# Altium schematic sheet PMB_top_v2.SchDoc
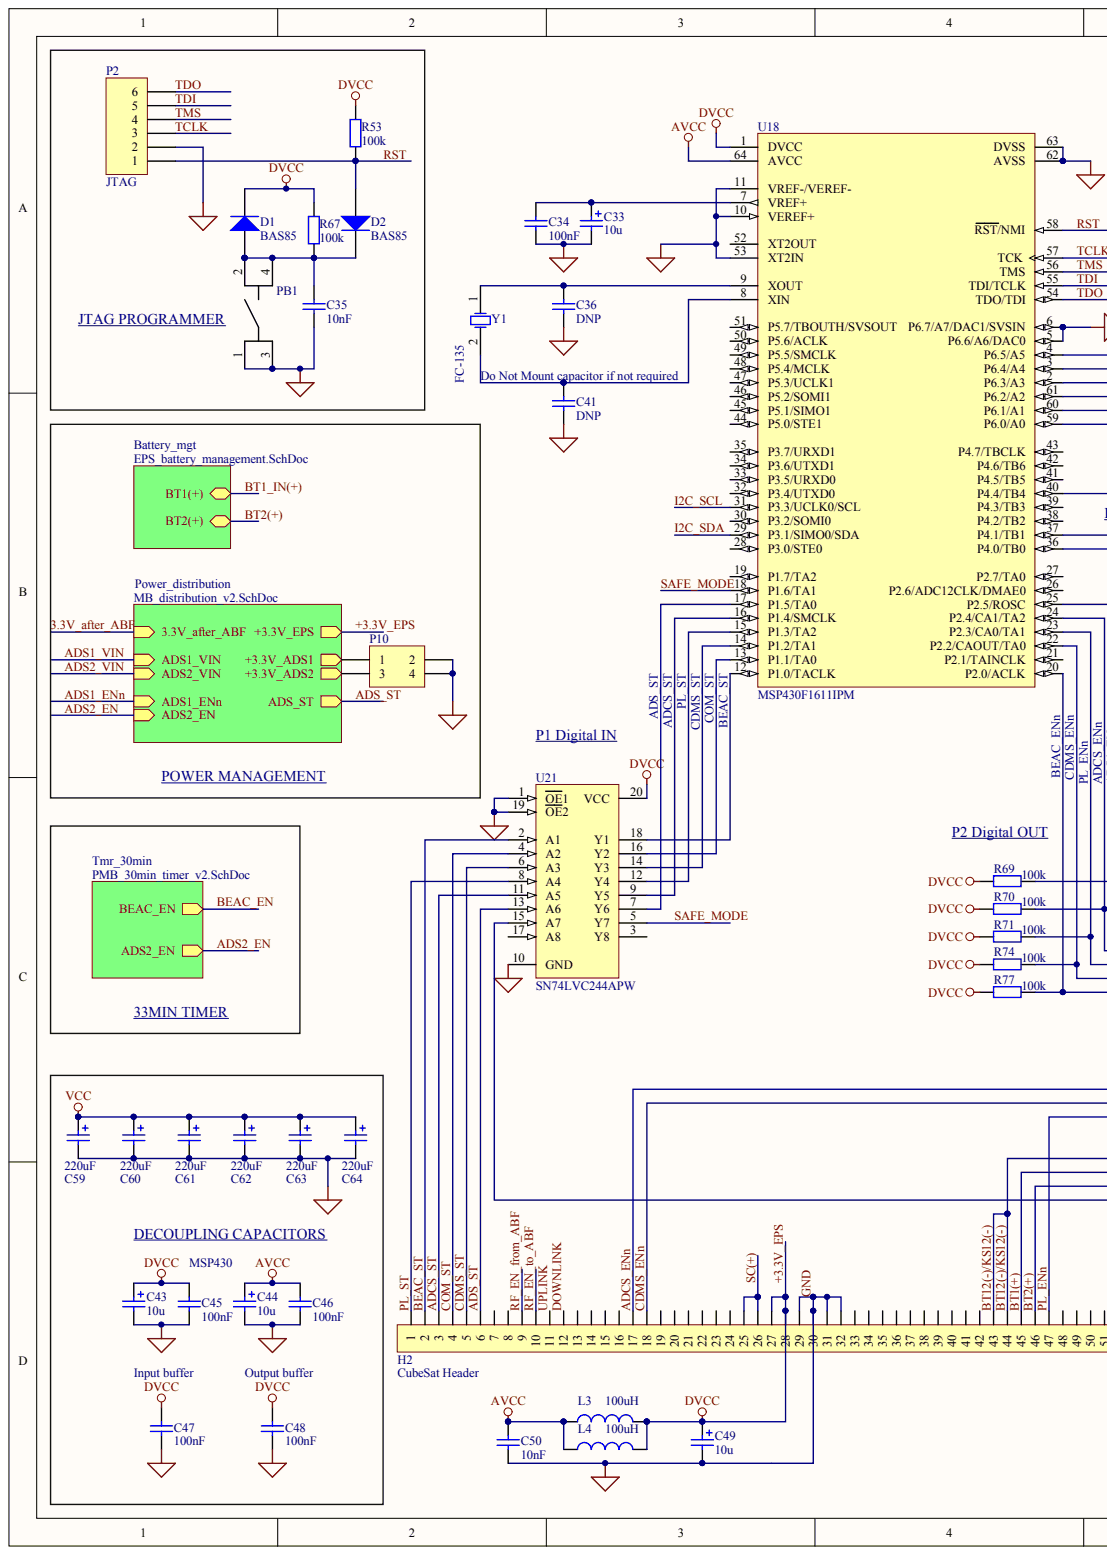
[diagram p0: PMB_top_v2.SchDoc, left]
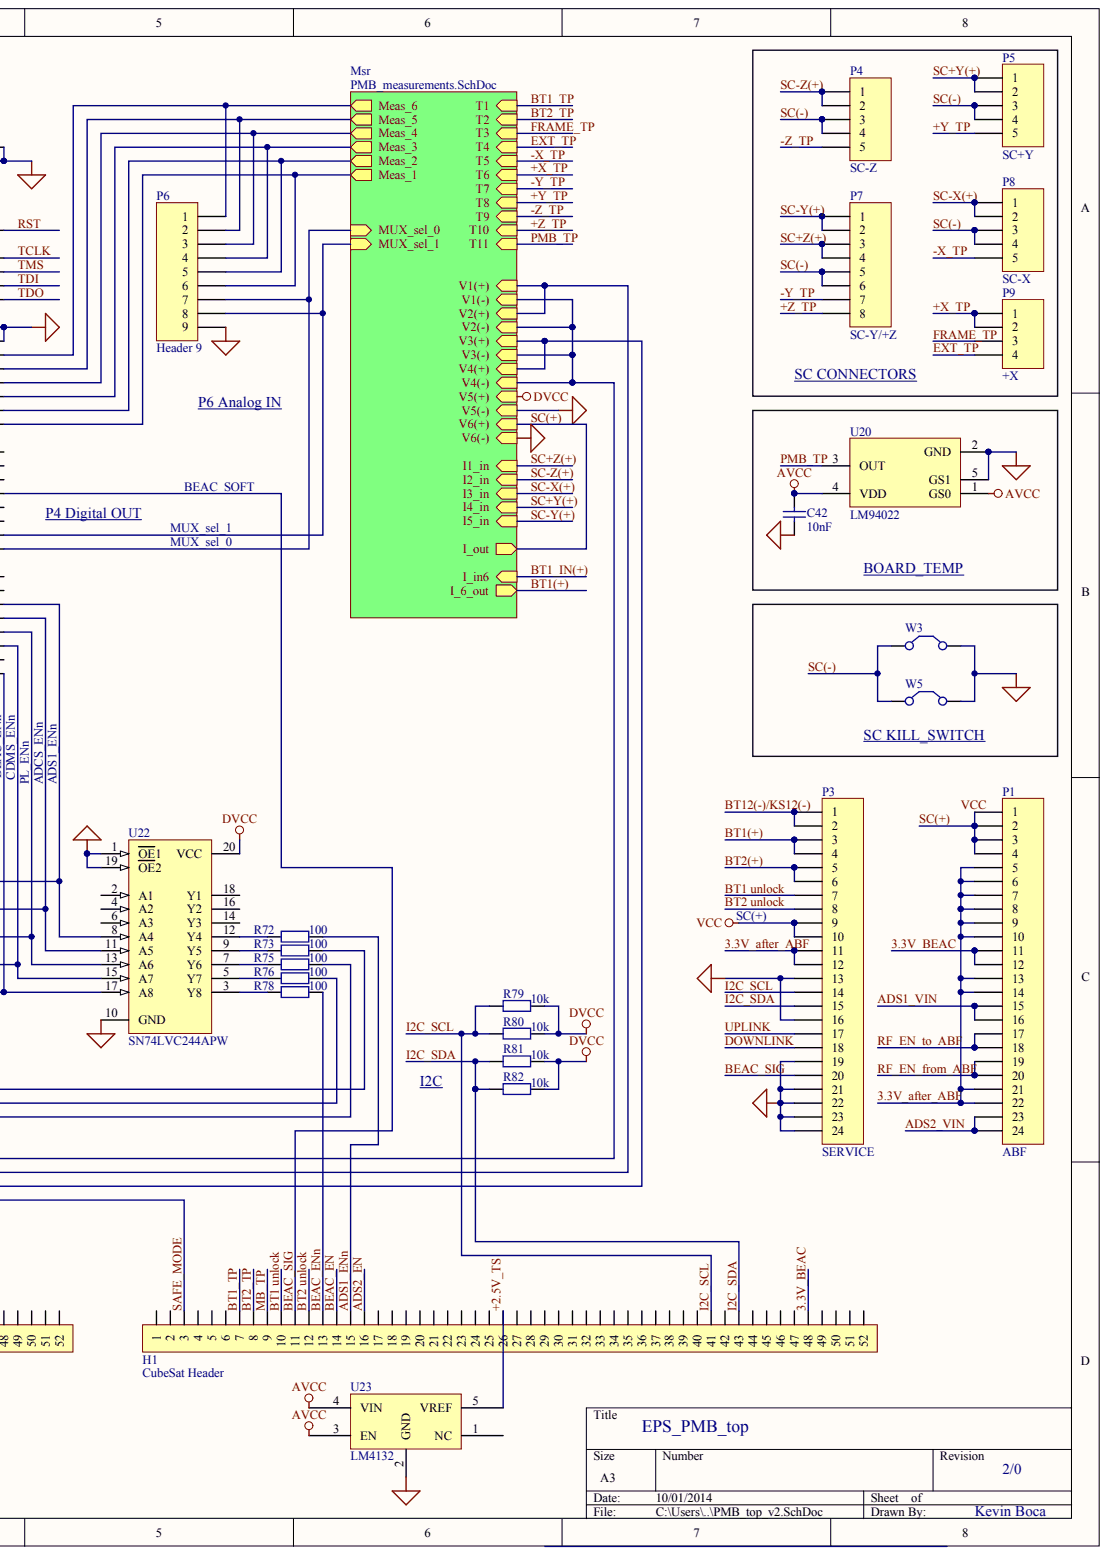
[diagram p1: PMB_top_v2.SchDoc, right]

## Parts
C33  10u
C34  100nF
C35  10nF
C36  DNP
C41  DNP
C42  10nF
C43  10u
C44  10u
C45  100nF
C46  100nF
C47  100nF
C48  100nF
C49  10u
C50  10nF
C59  220uF
C60  220uF
C61  220uF
C62  220uF
C63  220uF
C64  220uF
D1  BAS85
D2  BAS85
H1  CubeSat Header
H2  CubeSat Header
L3  100uH
L4  100uH
P1  ABF
P2  JTAG
P3  SERVICE
P4  SC-Z
P5  SC+Y
P6  Header 9
P7  SC-Y/+Z
P8  SC-X
P9  +X
P10  Header 2X2  (value not drawn)
PB1  PB
R53  100k
R67  100k
R69  100k
R70  100k
R71  100k
R72  100
R73  100
R74  100k
R75  100
R76  100
R77  100k
R78  100
R79  10k
R80  10k
R81  10k
R82  10k
U18  MSP430F1611IPM
U20  LM94022
U21  SN74LVC244APW
U22  SN74LVC244APW
U23  LM4132
W3  Jumper  (value not drawn)
W5  Jumper  (value not drawn)
Y1  FC-135

## Nets
AVCC: C42.1 C44.1 C46.2 C50.2 L3.1 L4.1 U18.64(AVCC) U20.1(GS0) U20.4(VDD) U23.3(EN) U23.4(VIN)
3.3V_AFTER_ABF: P1.5 P1.6 P1.7 P1.8 P1.9 P1.10 P1.13 P1.14 P1.21 P1.22 P3.11 P3.12
3.3V_BEAC: H1.48 P1.11 P1.12
+2.5V_TS: H1.26 U23.5(VREF)
+3.3V_EPS: C43.1 C45.2 C47.2 C48.2 C49.1 D1.1 H2.27 H2.28 L3.2 L4.2 R53.1 R67.1 R69.2 R70.2 R71.2 R74.2 R77.2 R79.1 R80.1 R81.1 R82.1 U18.1(DVCC) U21.20(VCC) U22.20(VCC)
+X_TP: P9.1 P9.2
+Y_TP: P5.5
+Z_TP: P7.8
-X_TP: P8.5
-Y_TP: P7.7
-Z_TP: P4.5
ADCS_ENN: H2.17 R73.1
ADCS_ST: H2.3 U21.11(A5)
ADS1_ENN: H1.15 R72.1
ADS1_VIN: P1.15 P1.16
ADS2_EN: H1.16
ADS2_VIN: P1.23 P1.24
ADS_ST: H2.6 U21.13(A6)
BEAC_EN: H1.14
BEAC_ENN: H1.13 R78.1
BEAC_SIG: H1.11 P3.20 U18.40(P4.4/TB4)
BEAC_ST: H2.2 U21.2(A1)
BT1 UNLOCK: H1.10 P3.7
BT1(+): H2.45 P3.3 P3.4
BT1_TP: H1.7
BT2 UNLOCK: H1.12 P3.8
BT2(+): H2.46 P3.5 P3.6
BT2_TP: H1.8
BT12(-)/KS12(-): H2.43 H2.44 P3.1 P3.2
CDMS_ENN: H2.18 R76.1
CDMS_ST: H2.5 U21.6(A3)
COM_ST: H2.4 U21.4(A2)
DOWNLINK: H2.12 P3.18
EXT_TP: P9.4
FRAME_TP: P9.3
GND: C33.2 C34.1 C35.1 C36.1 C41.1 C42.2 C43.2 C44.2 C45.1 C46.1 C47.1 C48.1 C49.2 C50.1 C59.2 C60.2 C61.2 C62.2 C63.2 C64.2 H2.29 H2.30 H2.31 H2.32 P2.2 P3.13 P3.16 P3.19 P3.21 P3.22 P3.23 P3.24 P6.9 P10.2 P10.4 PB1.1 PB1.3 U18.5(P6.6/A6/DAC0) U18.6(P6.7/A7/DAC1/SVSIN) U18.10(VEREF+) U18.11(VREF-/VEREF-) U18.53(XT2IN) U18.62(AVSS) U18.63(DVSS) U20.2(GND) U20.5(GS1) U21.1(OE1) U21.10(GND) U21.19(OE2) U22.1(OE1) U22.10(GND) U22.19(OE2) U23.2(GND) W3.2 W5.2
I2C_SCL: H1.41 P3.14 R79.2 R80.2 U18.31(P3.3/UCLK0/SCL)
I2C_SDA: H1.43 P3.15 R81.2 R82.2 U18.29(P3.1/SIMO0/SDA)
MB_TP: H1.9
PL_ENN: H2.47 R75.1
PL_ST: H2.1 U21.8(A4)
PMB_TP: U20.3(OUT)
RF_EN_FROM_ABF: H2.9 P1.19 P1.20
RF_EN_TO_ABF: H2.10 P1.17 P1.18
RST: D2.2 P2.1 R53.2 U18.58(RST/NMI)
SAFE_MODE: H1.3 U18.18(P1.6/TA1) U21.5(Y7) U21.15(A7)
SC(+): H2.25 H2.26 P1.1 P1.2 P1.3 P1.4
SC(-): P4.3 P4.4 P5.3 P5.4 P7.5 P7.6 P8.3 P8.4 W3.1 W5.1
SC+Y(+): P5.1 P5.2
SC+Z(+): P7.3 P7.4
SC-X(+): P8.1 P8.2
SC-Y(+): P7.1 P7.2
SC-Z(+): P4.1 P4.2
TCLK: P2.3 U18.57(TCK)
TDI: P2.5 U18.55(TDI/TCLK)
TDO: P2.6 U18.54(TDO/TDI)
TMS: P2.4 U18.56(TMS)
UPLINK: H2.11 P3.17
VCC: C59.1 C60.1 C61.1 C62.1 C63.1 C64.1 P3.9 P3.10
NetC33_1: C33.1 C34.2 U18.7(VREF+)
NetC35_2: C35.2 D1.2 D2.1 PB1.2 PB1.4 R67.2
NetC36_2: C36.2 U18.9(XOUT) Y1.1
NetC41_2: C41.2 U18.8(XIN) Y1.2
NetH1_1: H1.1
NetH1_2: H1.2
NetH1_4: H1.4
NetH1_5: H1.5
NetH1_6: H1.6
NetH1_17: H1.17
NetH1_18: H1.18
NetH1_19: H1.19
NetH1_20: H1.20
NetH1_21: H1.21
NetH1_22: H1.22
NetH1_23: H1.23
NetH1_24: H1.24
NetH1_25: H1.25
NetH1_27: H1.27
NetH1_28: H1.28
NetH1_29: H1.29
NetH1_30: H1.30
NetH1_31: H1.31
NetH1_32: H1.32
NetH1_33: H1.33
NetH1_34: H1.34
NetH1_35: H1.35
NetH1_36: H1.36
NetH1_37: H1.37
NetH1_38: H1.38
NetH1_39: H1.39
NetH1_40: H1.40
NetH1_42: H1.42
NetH1_44: H1.44
NetH1_45: H1.45
NetH1_46: H1.46
NetH1_47: H1.47
NetH1_49: H1.49
NetH1_50: H1.50
NetH1_51: H1.51
NetH1_52: H1.52
NetH2_7: H2.7
NetH2_8: H2.8
NetH2_13: H2.13
NetH2_14: H2.14
NetH2_15: H2.15
NetH2_16: H2.16
NetH2_19: H2.19
NetH2_20: H2.20
NetH2_21: H2.21
NetH2_22: H2.22
NetH2_23: H2.23
NetH2_24: H2.24
NetH2_33: H2.33
NetH2_34: H2.34
NetH2_35: H2.35
NetH2_36: H2.36
NetH2_37: H2.37
NetH2_38: H2.38
NetH2_39: H2.39
NetH2_40: H2.40
NetH2_41: H2.41
NetH2_42: H2.42
NetH2_48: H2.48
NetH2_49: H2.49
NetH2_50: H2.50
NetH2_51: H2.51
NetH2_52: H2.52
NetP6_1: P6.1 U18.4(P6.5/A5)
NetP6_2: P6.2 U18.3(P6.4/A4)
NetP6_3: P6.3 U18.2(P6.3/A3)
NetP6_4: P6.4 U18.61(P6.2/A2)
NetP6_5: P6.5 U18.60(P6.1/A1)
NetP6_6: P6.6 U18.59(P6.0/A0)
NetP6_7: P6.7 U18.36(P4.0/TB0)
NetP6_8: P6.8 U18.37(P4.1/TB1)
NetP10_1: P10.1
NetP10_3: P10.3
NetR69_1: R69.1 U18.25(P2.5/ROSC) U22.8(A4)
NetR70_1: R70.1 U18.24(P2.4/CA1/TA2) U22.11(A5)
NetR71_1: R71.1 U18.23(P2.3/CA0/TA1) U22.13(A6)
NetR72_2: R72.2 U22.12(Y4)
NetR73_2: R73.2 U22.9(Y5)
NetR74_1: R74.1 U18.22(P2.2/CAOUT/TA0) U22.15(A7)
NetR75_2: R75.2 U22.7(Y6)
NetR76_2: R76.2 U22.5(Y7)
NetR77_1: R77.1 U18.20(P2.0/ACLK) U22.17(A8)
NetR78_2: R78.2 U22.3(Y8)
NetU18_12: U18.12(P1.0/TACLK) U21.18(Y1)
NetU18_13: U18.13(P1.1/TA0) U21.16(Y2)
NetU18_14: U18.14(P1.2/TA1) U21.14(Y3)
NetU18_15: U18.15(P1.3/TA2) U21.12(Y4)
NetU18_16: U18.16(P1.4/SMCLK) U21.9(Y5)
NetU18_17: U18.17(P1.5/TA0) U21.7(Y6)
NetU18_19: U18.19(P1.7/TA2)
NetU18_21: U18.21(P2.1/TAINCLK)
NetU18_26: U18.26(P2.6/ADC12CLK/DMAE0)
NetU18_27: U18.27(P2.7/TA0)
NetU18_28: U18.28(P3.0/STE0)
NetU18_30: U18.30(P3.2/SOMI0)
NetU18_32: U18.32(P3.4/UTXD0)
NetU18_33: U18.33(P3.5/URXD0)
NetU18_34: U18.34(P3.6/UTXD1)
NetU18_35: U18.35(P3.7/URXD1)
NetU18_38: U18.38(P4.2/TB2)
NetU18_39: U18.39(P4.3/TB3)
NetU18_41: U18.41(P4.5/TB5)
NetU18_42: U18.42(P4.6/TB6)
NetU18_43: U18.43(P4.7/TBCLK)
NetU18_44: U18.44(P5.0/STE1)
NetU18_45: U18.45(P5.1/SIMO1)
NetU18_46: U18.46(P5.2/SOMI1)
NetU18_47: U18.47(P5.3/UCLK1)
NetU18_48: U18.48(P5.4/MCLK)
NetU18_49: U18.49(P5.5/SMCLK)
NetU18_50: U18.50(P5.6/ACLK)
NetU18_51: U18.51(P5.7/TBOUTH/SVSOUT)
NetU18_52: U18.52(XT2OUT)
NetU21_3: U21.3(Y8)
NetU21_17: U21.17(A8)
NetU22_2: U22.2(A1)
NetU22_4: U22.4(A2)
NetU22_6: U22.6(A3)
NetU22_14: U22.14(Y3)
NetU22_16: U22.16(Y2)
NetU22_18: U22.18(Y1)
NetU23_1: U23.1(NC)
BT1_IN(+):
N00041:

## Library data of the parts
L3 L4 = (no symbol name): Code_IEC: 1005; Published: 8-Jun-2000; LatestRevisionDate: 29-May-2009; LatestRevisionNote: IPC-7351 Footprint Added.; PackageReference: 0402-A; PackageDescription: Chip Inductor; Code_JEITA: 0402; Value: 100uH; Publisher: Altium Limited
U18 = (no symbol name): Manufacturer: Texas Instruments; ADC: 12-bit SAR; ADC Channels: 8; Brown Out Reset: Yes; DAC: 1; DMA: 3; Eco-Plan: Green (RoHS & no Sb/Br); Flash(KB): 48; Multiplier: 16x16; SRAM(B): 10240; SubFamily: 1 Series; Timers - 16-bit: 2; USART: 2 with I, 2C; DatasheetVersion: SLAS368G; PackageVersion: 4040152/C; PackageReference: PM64; PackageDescription: 64-Pin Plastic Quad Flat Pack, Body 10 x 10 mm, Pitch 0.5 mm; Supplier 1: Digi-Key; Supplier Part Number 1: 296-18101-2-ND; Supplier 2: Digi-Key; Supplier Part Number 2: 296-18101-1-ND; Supplier 3: Digi-Key; Supplier Part Number 3: 296-18101-6-ND; Supplier 4: Farnell; Supplier Part Number 4: 1649392; Supplier 5: Mouser; Supplier Part Number 5: 595-MSP430F1610IRTDT; Comparators: Yes; Frequency(MHz): 8; GPIO: 48; SVS: Yes; Temp Sensor: Yes; Watchdog: Yes; Mounting Technology: Surface Mount; Code_JEDEC: MS-026; PartNumber: MSP430F1611IPM; ComponentLink1Description: Manufacturer URL; ComponentLink1URL: http://www.ti.com/; ComponentLink2Description: Datasheet; ComponentLink2URL: http://www.ti.com/general/docs/lit/getliterature.tsp?genericPartNumber=MSP430F1611&fileType=pdf; ComponentLink3Description: Package Specification; ComponentLink3URL: http://www.ti.com/lit/ml/mtqf008a/mtqf008a.pdf
U21 U22 = (no symbol name): ComponentAvailability: Obsolete; Published: 8-Jun-2000; LatestRevisionDate: 16-Oct-2006; LatestRevisionNote: IPC-7351 Footprint Added.; DatasheetVersion: Mar-1994; PackageVersion: Feb-1999; PackageReference: PW020; PackageDescription: 20-Pin Thin Small Outline Package 0.65 mm Pitch; ComponentLink1Description: Manufacturer Link; ComponentLink1URL: http://www.ti.com/; ComponentLink2Description: Discontinuation Notice; ComponentLink2URL: http://focus.ti.com/docs/prod/folders/print/sn74lvc244.html; Publisher: Altium Limited
C33-C36 C41-C50 C59-C64 D1 D2 H1 H2 P1-P10 PB1 R53 R67 R69-R82 U20 U23 W3 W5 Y1 = (no symbol name)
  C33 C43 C44 C49: Published: 8-Jun-2000; LatestRevisionDate: 17-Jul-2002; LatestRevisionNote: Re-released for DXP Platform.; PackageReference: POLAR0.8; PackageDescription: Polarized Capacitor; 2 Leads; Value: 10u
  C34 C45-C48: Published: 8-Jun-2000; LatestRevisionDate: 17-Jul-2002; LatestRevisionNote: Re-released for DXP Platform.; PackageReference: RAD-0.3; PackageDescription: Radial Cap, Thru-Hole; 2 Leads; 0.3 in Pin Spacing; Value: 100nF
  C35 C42 C50: Published: 8-Jun-2000; LatestRevisionDate: 17-Jul-2002; LatestRevisionNote: Re-released for DXP Platform.; PackageReference: RAD-0.3; PackageDescription: Radial Cap, Thru-Hole; 2 Leads; 0.3 in Pin Spacing; Value: 10nF
  C36 C41: Published: 8-Jun-2000; LatestRevisionDate: 17-Jul-2002; LatestRevisionNote: Re-released for DXP Platform.; PackageReference: RAD-0.3; PackageDescription: Radial Cap, Thru-Hole; 2 Leads; 0.3 in Pin Spacing; Value: DNP
  C59-C64: Published: 8-Jun-2000; LatestRevisionDate: 17-Jul-2002; LatestRevisionNote: Re-released for DXP Platform.; PackageReference: POLAR0.8; PackageDescription: Polarized Capacitor; 2 Leads; Value: 220uF
  D1 D2: Published: 8-Jun-2000; LatestRevisionDate: 17-Jul-2002; LatestRevisionNote: Re-released for DXP Platform.; PackageVersion: Sep-1996; PackageReference: SMC; PackageDescription: DO-214-AB/SMC; 2 C-Bend Leads; Body 7.9 x 5.9 mm, inc. leads (LxW); Code_JEDEC: DO-214-AB
  P1-P10: LatestRevisionDate: 17-Jul-2002; LatestRevisionNote: Re-released for DXP Platform.
  R53 R67 R69-R71 R74 R77: Published: 8-Jun-2000; LatestRevisionDate: 17-Jul-2002; LatestRevisionNote: Re-released for DXP Platform.; PackageReference: AXIAL-0.4; PackageDescription: Axial Device, Thru-Hole; 2 Leads; 0.4 in Pin Spacing; Value: 100k
  R72 R73 R75 R76 R78: Published: 8-Jun-2000; LatestRevisionDate: 17-Jul-2002; LatestRevisionNote: Re-released for DXP Platform.; PackageReference: AXIAL-0.4; PackageDescription: Axial Device, Thru-Hole; 2 Leads; 0.4 in Pin Spacing; Value: 100
  R79-R82: Published: 8-Jun-2000; LatestRevisionDate: 17-Jul-2002; LatestRevisionNote: Re-released for DXP Platform.; PackageReference: AXIAL-0.4; PackageDescription: Axial Device, Thru-Hole; 2 Leads; 0.4 in Pin Spacing; Value: 10k
  W3 W5: Published: 24-Mar-1999; LatestRevisionDate: 17-Jul-2002; LatestRevisionNote: Re-released for DXP Platform.; PackageReference: RAD-0.2; PackageDescription: Radial Cap, Thru-Hole; 2 Leads; 0.2 in Pin Spacing
  Y1: Published: 8-Jun-2000; LatestRevisionDate: 17-Jul-2002; LatestRevisionNote: Re-released for DXP Platform.; PackageReference: R38; PackageDescription: Crystal, Thru-Hole; 2 Leads; Body 3.1 x 8.2 mm (Dia.xH)
  C33-C36 C41-C50 C59-C64 D1 D2 P1-P10 R53 R67 R69-R82 W3 W5 Y1: Publisher: Altium Limited
